# Altium schematic sheet Power supply.SchDoc
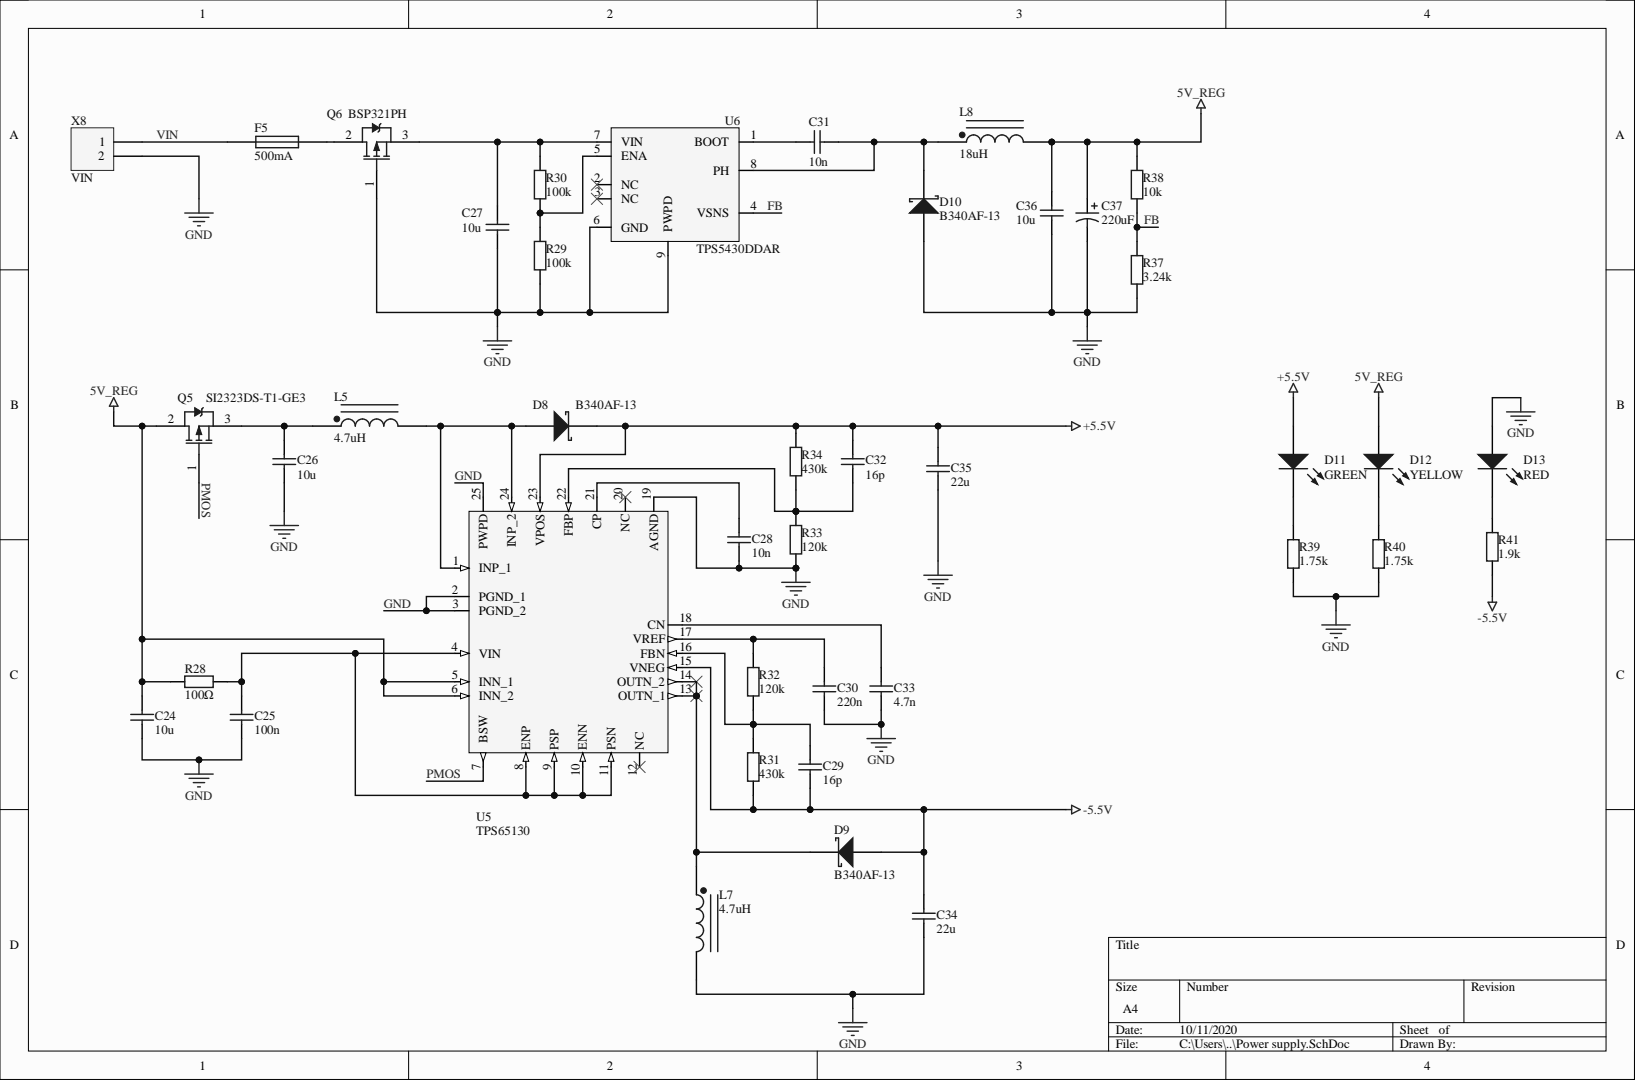
[diagram p0: Power supply.SchDoc]

## Parts
C24  10u  [CAPC2012X110N]
C25  100n
C26  10u  [CAPC2012X110N]
C27  10u  [CAPC2012X110N]
C28  10n
C29  16p
C30  220n
C31  10n
C32  16p
C33  4.7n
C34  22u
C35  22u
C36  10u  [CAPC2012X110N]
C37  220uF
D8  B340AF-13  [SODFL5226X110N]
D9  B340AF-13  [SODFL5226X110N]
D10  B340AF-13  [SODFL5226X110N]
D11  GREEN
D12  YELLOW
D13  RED
F5  500mA
L5  4.7uH  [B82462G4472M]
L7  4.7uH  [B82462G4472M]
L8  18uH  [SRR4828A180M]
Q5  SI2323DS-T1-GE3  [SOT95P237X112-3N]
Q6  BSP321PH  [SOT230P700X170-4N]
R28  100Ω
R29  100k  [RESC1608X55N]
R30  100k  [RESC1608X55N]
R31  430k  [RESC1608X55N]
R32  120k  [RESC1608X55N]
R33  120k  [RESC1608X55N]
R34  430k  [RESC1608X55N]
R37  3.24k  [RESC1608X55N]
R38  10k  [RESC1608X55N]
R39  1.75k  [RESC1608X55N]
R40  1.75k  [RESC1608X55N]
R41  1.9k  [RESC1608X55N]
U5  TPS65130  [QFN50P400X400X100-25N-D]
U6  TPS5430DDAR  [SOIC127P600X170-9N]
X8  VIN

## Nets
5V_REG: C24.2 C36.2 C37.1 D12.1 L8.2 Q5.2 R28.1 R38.2 U5.5(INN_1) U5.6(INN_2)
+5.5V: C32.2 C35.2 D8.2 D11.1 R34.2 U5.23(VPOS)
-5.5V: C29.1 C34.2 D9.1 R31.1 R41.1 U5.15(VNEG)
FB: R37.2 R38.1 U6.4(VSNS)
GND: C24.1 C25.1 C26.1 C27.1 C28.1 C30.1 C33.1 C34.1 C35.1 C36.1 C37.2 D10.1 D13.1 L7.2 Q6.1 R29.1 R33.1 R37.1 R39.2 R40.2 U5.2(PGND_1) U5.3(PGND_2) U5.19(AGND) U5.25(PWPD) U6.6(GND) U6.9(PWPD) X8.2
PMOS: Q5.1 U5.7(BSW)
VIN: F5.2 X8.1
NetC25_2: C25.2 R28.2 U5.4(VIN) U5.8(ENP) U5.9(PSP) U5.10(ENN) U5.11(PSN)
NetC26_2: C26.2 L5.1 Q5.3
NetC27_2: C27.2 Q6.3 R30.2 U6.7(VIN)
NetC28_2: C28.2 U5.21(CP)
NetC29_2: C29.2 R31.2 R32.1 U5.16(FBN)
NetC30_2: C30.2 R32.2 U5.17(VREF)
NetC31_1: C31.1 U6.1(BOOT)
NetC31_2: C31.2 D10.2 L8.1 U6.8(PH)
NetC32_1: C32.1 R33.2 R34.1 U5.22(FBP)
NetC33_2: C33.2 U5.18(CN)
NetD8_1: D8.1 L5.2 U5.1(INP_1) U5.24(INP_2)
NetD9_2: D9.2 L7.1 U5.13(OUTN_1) U5.14(OUTN_2)
NetD11_2: D11.2 R39.1
NetD12_2: D12.2 R40.1
NetD13_2: D13.2 R41.2
NetF5_1: F5.1 Q6.2
NetR29_2: R29.2 R30.1 U6.5(ENA)

Not connected: U5 12(NC) 20(NC); U6 2(NC) 3(NC)

## Library data of the parts
C24 C26 C27 C36 = Cap: Manufacturer 1: Taiyo Yuden; Manufacturer Part Number 1: EMK212BB7106KG-T; Component Kind: Standard; Library Name: Miscellaneous Devices.IntLib; Signal Integrity: Cap; Simulation: CAP
C25 C28-C35 = Cap: Component Kind: Standard; Library Name: Miscellaneous Devices.IntLib; Signal Integrity: Cap; Simulation: CAP
C37 = Cap Pol1: Component Kind: Standard; Library Name: Miscellaneous Devices.IntLib; Signal Integrity: Cap Pol; Simulation: CAP
D8-D10 = D Schottky: Manufacturer 1: Diodes Incorporated; Component Kind: Standard; Library Name: Miscellaneous Devices.IntLib; Manufacturer Part Number 1: B340AF-13; Signal Integrity: D Schottky; Simulation: SKYDIODE
D11-D13 = LED1: Component Kind: Standard; Library Name: Miscellaneous Devices.IntLib; Simulation: LED1
F5 = Fuse 1: Component Kind: Standard; Library Name: Miscellaneous Devices.IntLib; Simulation: FUSE
L5 L7 = Inductor Iron Dot: Manufacturer 1: EPCOS/TDK; Component Kind: Standard; Library Name: Miscellaneous Devices.IntLib; Manufacturer Part Number 1: B82462G4472M; Signal Integrity: Inductor Iron Dot
L8 = Inductor Iron Dot: Manufacturer 1: Bourns; Manufacturer Part Number 1: SRR4828A-180M; Component Kind: Standard; Library Name: Miscellaneous Devices.IntLib; Signal Integrity: Inductor Iron Dot
Q5 = PMOS-2: Manufacturer 1: Vishay Semiconductor; Component Kind: Standard; Library Name: Miscellaneous Devices.IntLib; Manufacturer Part Number 1: SI2323DS-T1-GE3; Simulation: IRF9510
Q6 = PMOS-2: Manufacturer 1: Infineon; Manufacturer Part Number 1: BSP321PH6327XTSA1; Component Kind: Standard; Library Name: Miscellaneous Devices.IntLib; Simulation: IRF9510
R28 R39-R41 = Res2: Component Kind: Standard; Library Name: Miscellaneous Devices.IntLib; Signal Integrity: Res; Simulation: RESISTOR
R29 R30 = Res2: Manufacturer 1: Panasonic; Manufacturer Part Number 1: ERJ-3EKF1003V; Component Kind: Standard; Library Name: Miscellaneous Devices.IntLib; Signal Integrity: Res; Simulation: RESISTOR
R31 R34 = Res2: Manufacturer 1: Bourns; Manufacturer Part Number 1: CR0603-FX-4303ELF; Component Kind: Standard; Library Name: Miscellaneous Devices.IntLib; Signal Integrity: Res; Simulation: RESISTOR
R32 R33 = Res2: Manufacturer 1: Bourns; Manufacturer Part Number 1: CR0603-FX-1203ELF; Component Kind: Standard; Library Name: Miscellaneous Devices.IntLib; Signal Integrity: Res; Simulation: RESISTOR
R37 = Res2: Manufacturer 1: Vishay/Dale; Manufacturer Part Number 1: CRCW06033K24FKEA; Component Kind: Standard; Library Name: Miscellaneous Devices.IntLib; Signal Integrity: Res; Simulation: RESISTOR
R38 = Res2: Manufacturer 1: Yageo; Manufacturer Part Number 1: RC0603FR-0710KL; Component Kind: Standard; Library Name: Miscellaneous Devices.IntLib; MOUSER Part Number: 603-RC0603FR-0710KL; Signal Integrity: Res; Simulation: RESISTOR
U5 = TPS65130: Manufacturer 1: Texas Instruments; Manufacturer Part Number 1: TPS65130RGER; Component Kind: Standard; Library Name: Custom_Lib.SchLib
U6 = TPS5430: Manufacturer 1: Texas Instruments; Component Kind: Standard; Library Name: Custom_Lib.SchLib; Manufacturer Part Number 1: TPS5430DDAR
X8 = Header 2: Component Kind: Standard; Library Name: Miscellaneous Connectors.IntLib; Signal Integrity: Connector
